# Altium schematic sheet Connector Interface.SchDoc
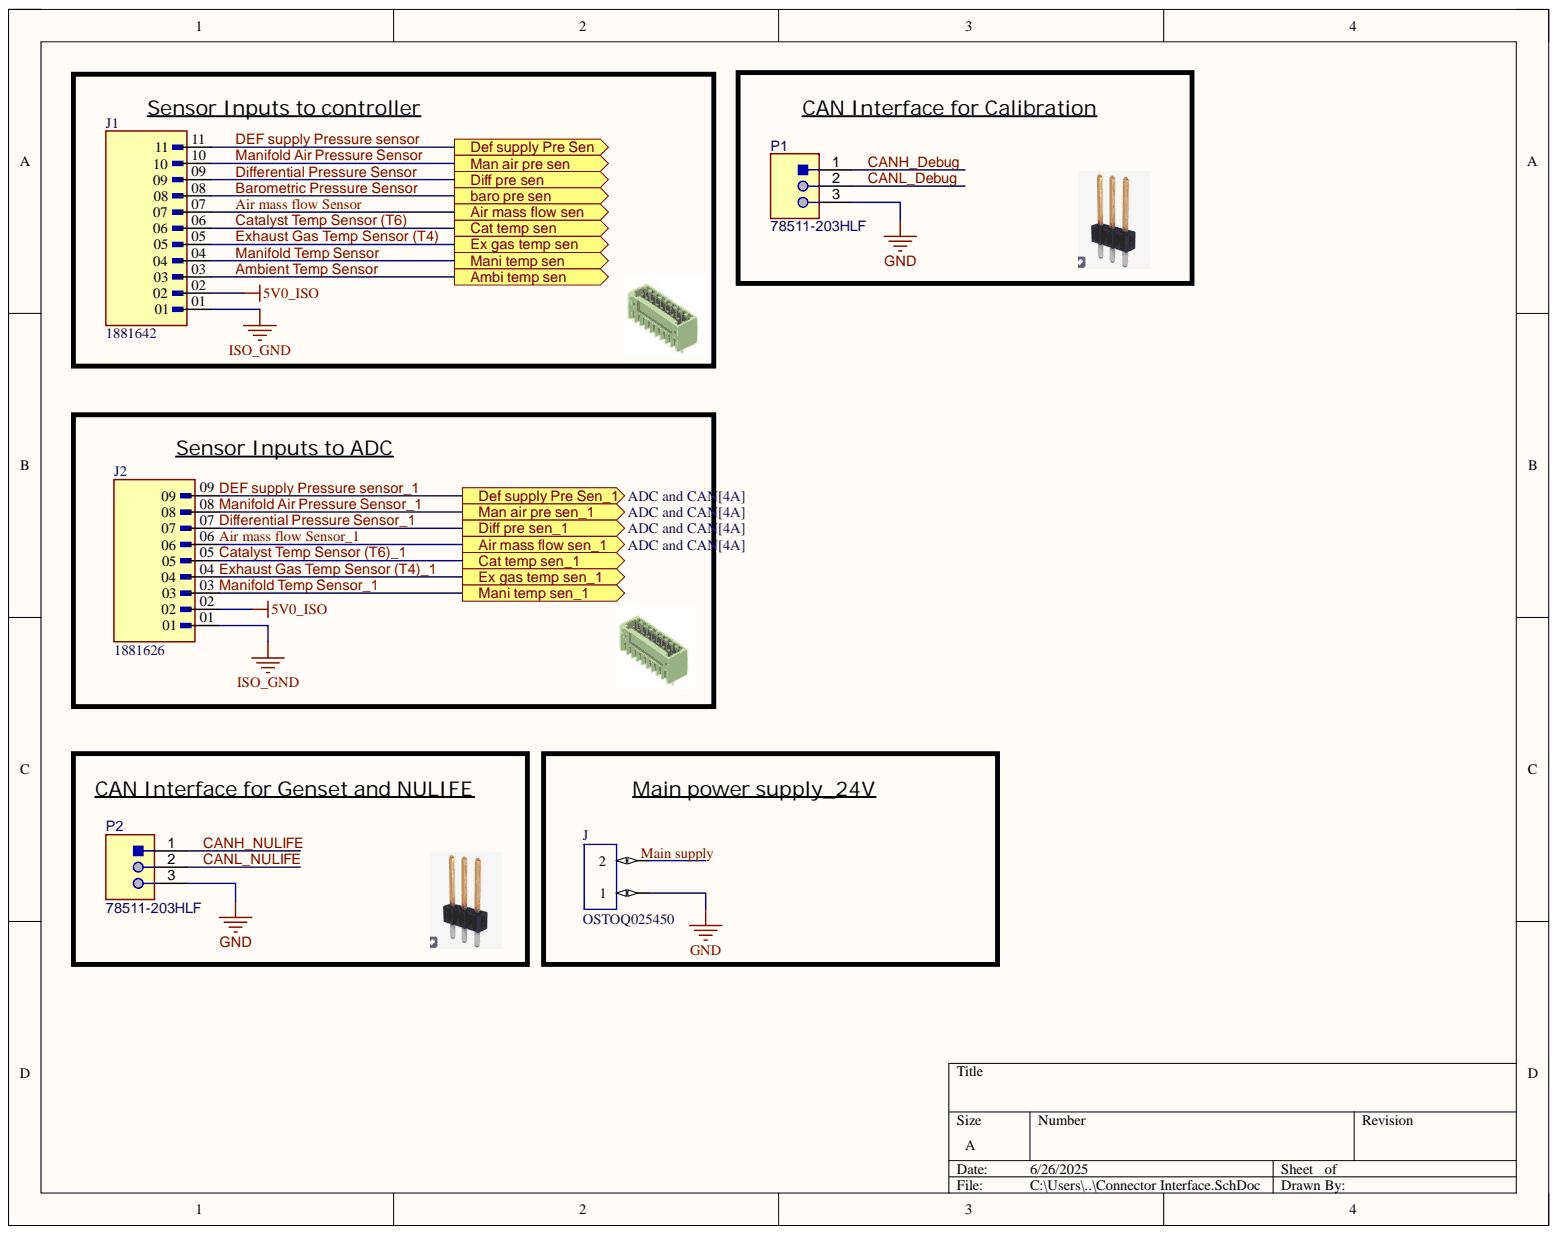
[diagram p0: Connector Interface.SchDoc]

## Parts
J  OSTOQ025450  [OSTOQ025450]
J1  1881642  [PHOENIX_1881642]
J2  1881626  [PHOENIX_1881626]
P1  78511-203HLF
P2  78511-203HLF

## Ports
Air mass flow sen, Air mass flow sen_1, Ambi temp sen, baro pre sen, Cat temp sen, Cat temp sen_1, Def supply Pre Sen, Def supply Pre Sen_1, Diff pre sen, Diff pre sen_1, Ex gas temp sen, Ex gas temp sen_1, Man air pre sen, Man air pre sen_1, Mani temp sen, Mani temp sen_1

## Nets
5V0_ISO: J1.02 J2.02
GND: J.1 P1.3 P2.3
ISO_GND: J1.01 J2.01
Air mass flow Sensor: J1.07 ; port Air mass flow sen
Air mass flow Sensor_1: J2.06 ; port Air mass flow sen_1
Ambient Temp Sensor: J1.03 ; port Ambi temp sen
Barometric Pressure Sensor: J1.08 ; port baro pre sen
CANH_Debug: P1.1
CANH_NULIFE: P2.1
CANL_Debug: P1.2
CANL_NULIFE: P2.2
Catalyst Temp Sensor (T6): J1.06 ; port Cat temp sen
Catalyst Temp Sensor (T6)_1: J2.05 ; port Cat temp sen_1
DEF supply Pressure sensor: J1.11 ; port Def supply Pre Sen
DEF supply Pressure sensor_1: J2.09 ; port Def supply Pre Sen_1
Differential Pressure Sensor: J1.09 ; port Diff pre sen
Differential Pressure Sensor_1: J2.07 ; port Diff pre sen_1
Exhaust Gas Temp Sensor (T4): J1.05 ; port Ex gas temp sen
Exhaust Gas Temp Sensor (T4)_1: J2.04 ; port Ex gas temp sen_1
Manifold Air Pressure Sensor: J1.10 ; port Man air pre sen
Manifold Air Pressure Sensor_1: J2.08 ; port Man air pre sen_1
Manifold Temp Sensor: J1.04 ; port Mani temp sen
Manifold Temp Sensor_1: J2.03 ; port Mani temp sen_1
Main supply:

Not connected: J 2

## Library data of the parts
J = OSTOQ025450: Cabe莽alho do bloco de terminais de 2 posi莽玫es, pinos masculinos, encoberto _4 lados_ 0.200 _5.08mm_ de solda vertical; MF: On Shore; Availability: Unavailable; Component Kind: Standard; Library Name: OSTOQ025450.IntLib; MP: OSTOQ025450; Package: None; Price: None; SnapEDA_Link: https://www.snapeda.com/parts/OSTOQ025450/On%20Shore%20Technology%20Inc./view-part/2873375/?ref=snap
J2 = 1881626: Pluggable Header 2.5mm 20AWG 160V 4A 9 Position MICRO-COMBICON MCV Series | Phoenix Contact 1881626; Check_prices: https://www.snapeda.com/parts/1881626/Phoenix+Contact/view-part/?ref=eda; Component Kind: Standard; Library Name: 1881626.IntLib; MF: Phoenix Contact; MP: 1881626; Package: None; SnapEDA_Link: https://www.snapeda.com/parts/1881626/Phoenix+Contact/view-part/?ref=snap
P1 P2 = (no symbol name): CONN HEADER VERT 3POS 2.54MM; Manufacturer: Amphenol ICC (FCI); Component Kind: Standard; Part Number: 78511-203HLF
J1 = 1881642: Availability: Unavailable; Component Kind: Standard; Library Name: 1881642.IntLib; MP: 1881642; Package: None; Price: None
